# Altium schematic sheet LPC55S69_MINI.SchDoc(LPC55S69_MINI) (continued)

## Library data of the parts
BTN1-BTN3 RESET = B3U-1100P: SWITCH TACTILE SPST-NO 0.05A 12V; Manufacturer: Omron Electronics Inc-EMC Div; Actuator Height off PCB, from Pins: 1.60mm; Actuator Orientation: Top Actuated; Actuator Type: Standard; CategorySort: 700; Contact Rating @ Voltage: 0.05A @ 12VDC; Illumination: Non-Illuminated; Ingress Protection: Dust Proof; Mechanical Life: 200,000 Cycles; Operating Force: 153gf; Outline: 3.00mm x 2.50mm; Switch Function: Off-Mom; Switch Travel: 0.15mm; Actuator Material: -; Category: Switches; Circuit: SPST-NO; Component Kind: Standard; ComponentLink1Description: http://www.components.omron.com/components/web/PDFLIB.nsf/0/2DD3893C7DD33E9E862572900079E9D6/$file/B3U_1110.pdf; ComponentLink1URL: http://www.components.omron.com/components/web/PDFLIB.nsf/0/2DD3893C7DD33E9E862572900079E9D6/$file/B3U_1110.pdf; Features: Grounding Pin; Illumination Type, Color: -; Illumination Voltage (Nominal): -; Library Name: Switches-N.SchLib; Manufacturer Part Number: B3U-1100P; Mounting Type: Surface Mount; Operating Temperature: -25°C ~ 70°C; Packaging: Cut Tape (CT); Pricing 1: 1=1.25, 10=1.201, 25=1.1332, 50=0.997, 100=0.9064, 250=0.86108, 500=0.83842, 1000=0.65714 (USD); RoHS: RoHS Compliant; Stock 1: 45170; Supplier 1: Digi-Key; Supplier Part Number 1: SW1021CT-ND; Termination Style: SMD (SMT) Tab; Value: B3U-1100P
C1-C4 C10 = CGA4J1X7R0J106K125AC: CAP CER 10UF 6.3V X7R 0805; Manufacturer: TDK Corporation; Database Table Name: C0805$; Substitution Notes: 10µF , 6.3V , ±10% , X7R , -55°C ~ 125°C , AEC-Q200 , 0805; Tolerance: ±10%; Category: Capacitors; Component Kind: Standard; ComponentLink1Description: Datasheet (Internal); ComponentLink1URL: datasheets\TDK\mlcc_automotive_general_en.pdf; ComponentLink2Description: Datasheet (External); ComponentLink2URL: https://product.tdk.com/info/en/catalog/datasheets/mlcc_automotive_general_en.pdf; Features: -; Library Name: Capacitors - TDK- AEC-Q200.DbLib; Manufacturer Part Number: CGA4J1X7R0J106K125AC; Operating Temperature: -55°C ~ 125°C; Package: 0805; Ratings: AEC-Q200; Supplier 1: Digikey; Supplier Part Number 1: 445-6971-1-ND; Temperature Coefficient: X7R; Thickness (Max): 1.45MM; Value: 10µF; Voltage - Rated: 6.3V
C5 C7-C9 C11-C13 = CGA2B1X7R1C104K050BC: CAP CER 0.1UF 16V X7R 0402; Manufacturer: TDK Corporation; Database Table Name: C0402$; Substitution Notes: 0.1µF , 16V , ±10% , X7R , -55°C ~ 125°C , AEC-Q200 , 0402; Tolerance: ±10%; Category: Capacitors; Component Kind: Standard; ComponentLink1Description: Datasheet (Internal); ComponentLink1URL: datasheets\TDK\mlcc_automotive_general_en.pdf; ComponentLink2Description: Datasheet (External); ComponentLink2URL: https://product.tdk.com/info/en/catalog/datasheets/mlcc_automotive_general_en.pdf; Features: -; Library Name: Capacitors - TDK- AEC-Q200.DbLib; Manufacturer Part Number: CGA2B1X7R1C104K050BC; Operating Temperature: -55°C ~ 125°C; Package: 0402; Ratings: AEC-Q200; Supplier 1: Digikey; Supplier Part Number 1: 445-5613-1-ND; Temperature Coefficient: X7R; Thickness (Max): 0.55MM; Value: 0.1µF; Voltage - Rated: 16V
C6 = CGA2B2C0G1H470J050BA: CAP CER 47PF 50V C0G 0402; Manufacturer: TDK Corporation; Database Table Name: C0402$; Substitution Notes: 47pF , 50V , ±5% , C0G, NP0 , -55°C ~ 125°C , AEC-Q200 , 0402; Temperature Coefficient: C0G, NP0; Tolerance: ±5%; Category: Capacitors; Component Kind: Standard; ComponentLink1Description: Datasheet (Internal); ComponentLink1URL: datasheets\TDK\mlcc_automotive_general_en.pdf; ComponentLink2Description: Datasheet (External); ComponentLink2URL: https://product.tdk.com/info/en/catalog/datasheets/mlcc_automotive_general_en.pdf; Features: -; Library Name: Capacitors - TDK- AEC-Q200.DbLib; Manufacturer Part Number: CGA2B2C0G1H470J050BA; Operating Temperature: -55°C ~ 125°C; Package: 0402; Ratings: AEC-Q200; Supplier 1: Digikey; Supplier Part Number 1: 445-5592-1-ND; Thickness (Max): 0.55MM; Value: 47pF; Voltage - Rated: 50V
C14 C15 = CGA2B2C0G1H180J050BA: CAP CER 18PF 50V C0G 0402; Manufacturer: TDK Corporation; Database Table Name: C0402$; Substitution Notes: 18pF , 50V , ±5% , C0G, NP0 , -55°C ~ 125°C , AEC-Q200 , 0402; Temperature Coefficient: C0G, NP0; Tolerance: ±5%; Category: Capacitors; Component Kind: Standard; ComponentLink1Description: Datasheet (Internal); ComponentLink1URL: datasheets\TDK\mlcc_automotive_general_en.pdf; ComponentLink2Description: Datasheet (External); ComponentLink2URL: https://product.tdk.com/info/en/catalog/datasheets/mlcc_automotive_general_en.pdf; Features: -; Library Name: Capacitors - TDK- AEC-Q200.DbLib; Manufacturer Part Number: CGA2B2C0G1H180J050BA; Operating Temperature: -55°C ~ 125°C; Package: 0402; Ratings: AEC-Q200; Supplier 1: Digikey; Supplier Part Number 1: 445-5587-1-ND; Thickness (Max): 0.55MM; Value: 18pF; Voltage - Rated: 50V
D1-D3 = BAT760-7: DIODE SCHOTTKY 30V 1A SOD323; Manufacturer: Diodes Inc; Capacitance @ Vr- F: 25pF @ 5V- 1MHz; CategorySort: 400; Current - Reverse Leakage @ Vr: 50µA @ 15V; Database Table Name: Schottky$; Diode Suffix: 1A_2C; Num Pins: 2; Speed: Fast Recovery =< 500ns- > 200mA (Io); Voltage - Forward (Vf) (Max) @ If: 550mV @ 1A; Category: Diodes; Component Kind: Standard; ComponentLink1Description: Internal Datasheet; ComponentLink1URL: http://www.diodes.com/datasheets/ds30498.pdf; ComponentLink2Description: External Datasheet; ComponentLink2URL: http://www.diodes.com/datasheets/ds30498.pdf; Current - Average Rectified (Io): 1A; Diode Type: Schottky; Library Name: Diodes.DbLib; Manufacturer Part Number: BAT760-7; Mounting Type: Surface Mount; Package: SC-76- SOD-323; Packaging: Cut Tape (CT); Reverse Recovery Time (trr): -; SortValue: BAT760-7; Supplier 1: Digi-Key; Supplier Device Package: SOD-323; Supplier Part Number 1: BAT760DICT-ND; Value: BAT760-7; Voltage - DC Reverse (Vr) (Max): 30V
J1 = S2B-PH-SM4-TB(LF)(SN): CONN HEADER PH SIDE 2POS 2MM SMD; Manufacturer: JST Sales America Inc; Connector Type: Header, Shrouded; Number of Positions: 2; Number of Positions Loaded: All; Pitch: 0.079" (2.00mm); Category: Connectors, Interconnects; Color: Natural; Component Kind: Standard; ComponentLink1Description: http://www.jst-mfg.com/product/pdf/eng/ePH.pdf; ComponentLink1URL: http://www.jst-mfg.com/product/pdf/eng/ePH.pdf; Contact Finish: Tin; Contact Finish Thickness: -; Contact Mating Length: -; Contact Type: Male Pin; Fastening Type: -; Features: Solder Retention; Library Name: eConnector_JST_PH.SchLib; Manufacturer Part Number: S2B-PH-SM4-TB(LF)(SN); Mounting Type: Surface Mount, Right Angle; Number of Rows: 1; Packaging: Cut Tape (CT); Pricing 1: 1=0.5, 10=0.457, 100=0.3809, 500=0.3047 (USD); RoHS: RoHS Compliant; Row Spacing: -; Stock 1: 17700; Supplier 1: Digi-Key; Supplier Part Number 1: 455-1749-1-ND; Termination: Solder; Value: S2B-PH-SM4-TB(LF)(SN)
J2 = 10103594-0001LF: CONN RCPT STD MICRO USB TYPE B; Manufacturer: FCI; Connector Type: USB - micro B; Gender: Receptacle; Micro USB B: Value; Notes: Similar to; Number of Contacts: 5; Specifications: USB 2.0; Category: Connectors, Interconnects; Component Kind: Standard; ComponentLink1Description: http://portal.fciconnect.com/res/en/pdffiles/doc_search/10103594.pdf; ComponentLink1URL: http://portal.fciconnect.com/res/en/pdffiles/doc_search/10103594.pdf; Features: Shielded, Solder Retention; Ingress Protection: -; Library Name: eConnector_USB.SchLib; Manufacturer Part Number: 10103594-0001LF; Mounting Type: Surface Mount, Right Angle, Horizontal; Packaging: Cut Tape (CT); Pricing 1: 1=1.1, 10=0.768, 100=0.517, 250=0.43912, 500=0.41716 (USD); RoHS: RoHS Compliant; Stock 1: 23288; Supplier 1: Digi-Key; Supplier Part Number 1: 609-4050-1-ND; Value: USB Micro B
J3 = CORTEX-DEBUG: SAMTEC - FTSH-105-01-F-DV - .050 X .050; Category: Connectors; Component Kind: Standard; Library Name: eConnector.SchLib; Manufacturer: SAMTEC; Manufacturer Part Number: FTSH-105-01-F-DV; Pricing 1: 1=0.974, 25000=0.835, 50000=0.774 (USD); Stock 1: 0; Supplier 1: Newark; Supplier Part Number 1: 01R3399; Value: FTSH-105-01-F-DV
J4 = DM3AT-SF-PEJM5: CONN MICRO SD R/A PUSH-PUSH SMD; Manufacturer: Hirose Electric Co Ltd; Card Type: Secure Digital - microSD™; Connector Type: Connector and Ejector; Insertion, Removal Method: Push In, Push Out; Number of Positions: 10 (8 + 2); Category: Connectors, Interconnects; Component Kind: Standard; ComponentLink1Description: http://www.hirose.co.jp/cataloge_hp/e60900232.pdf; ComponentLink1URL: http://www.hirose.co.jp/cataloge_hp/e60900232.pdf; Contact Finish: Gold; Contact Finish Thickness: -; Ejector Side: -; Features: Switch; Height Above Board: 0.066" (1.68mm); Library Name: eConnector_SD.SchLib; Manufacturer Part Number: DM3AT-SF-PEJM5; Mounting Feature: Normal, Standard - Top; Mounting Type: Surface Mount, Right Angle; Packaging: Cut Tape (CT); Pricing: 1=2.83, 10=2.72, 25=2.4932, 50=2.3798, 100=2.2664, 250=1.98312, 500=1.92648 (USD); RoHS: RoHS Compliant; Stock: 28699; Supplier 1: Digi-Key; Supplier Part Number 1: HR1964CT-ND; Value: DM3AT-SF-PEJM5
L1 = (no symbol name): FIXED IND 4.7UH 520MA 650 MOHM; Manufacturer: Taiyo Yuden; Core Material: Ferrite; DC Resistance (DCR): 650mR; Inductance Tolerance: 20%; Saturation Current: 360mA; Self Resonant Frequency: 45MHz; Shielding: Unshielded; Test Frequency: 7.96MHz; Case Code (Imperial): 0805; Case Code (Metric): 2012; Case/Package: 0805; Component Kind: Standard; ComponentLink1Description: https://datasheet.ciiva.com/15981/214cbc2012t4r7m-15981637.pdf?src-supplier=Onlinecomponents.com; ComponentLink1URL: https://datasheet.ciiva.com/15981/214cbc2012t4r7m-15981637.pdf?src-supplier=Onlinecomponents.com; ComponentLink2Description: https://datasheet.ciiva.com/1040/cbc2012t4r7m-ss-1040456.pdf?src-supplier=Digi-Key; ComponentLink2URL: https://datasheet.ciiva.com/1040/cbc2012t4r7m-ss-1040456.pdf?src-supplier=Digi-Key; ComponentLink3Description: https://datasheet.ciiva.com/8505/cbc2012t4r7m-ss-8505656.pdf?src-supplier=Avnet; ComponentLink3URL: https://datasheet.ciiva.com/8505/cbc2012t4r7m-ss-8505656.pdf?src-supplier=Avnet; ComponentLink4Description: https://datasheet.ciiva.com/8001/wound02-e-8001849.pdf?src-supplier=Element14; ComponentLink4URL: https://datasheet.ciiva.com/8001/wound02-e-8001849.pdf?src-supplier=Element14; Composition: Wirewound; Current Rating: 520mA; Halogen Free: Halogen Free; Height: 1.25mm; Height - Seated (Max): 0.057inch; Inductance: 4.7uH; Lead Free: Exempt; Length: 0.079inch; Library Name: eInductor.SchLib; Manufacturer Part Number: CBC2012T4R7M; Max DC Current: 520mA; Max Operating Temperature: 105°C; Min Operating Temperature: -40°C; Mount: Surface Mount; Number of Pins: 2; Package Quantity: 3000; Packaging: Tape and Reel; Pricing 1: 1=0.24000, 10=0.21600, 100=0.14860, 500=0.12702, 1000=0.09729 (USD); REACH SVHC: No SVHC; RoHS: Yes; Stock 1: 7720; Supplier 1: Digi-Key; Supplier Part Number 1: 587-1602-1-ND; Termination: SMD/SMT; Tolerance: 20%; Value: CBC2012T4R7M; Width: 0.049inch
L2 = (no symbol name): FERRITE BEAD 220 OHM 0402 1LN; Manufacturer: Taiyo Yuden; Current Rating (Max): 1A; DC Resistance (DCR) (Max): 150 mOhm; Filter Type: Power Line; Impedance @ Frequency: 220 Ohm @ 100MHz; Number of Lines: 1; Category: Filters; Component Kind: Standard; ComponentLink1Description: http://ds.yuden.co.jp/TYCOMPAS/ut/detail.do?productNo=BKP1005EM221-T&fileName=BKP1005EM221-T_SS&mode=specSheetDownload; ComponentLink1URL: http://ds.yuden.co.jp/TYCOMPAS/ut/detail.do?productNo=BKP1005EM221-T&fileName=BKP1005EM221-T_SS&mode=specSheetDownload; Height (Max): 0.022" (0.55mm); Library Name: eInductor.SchLib; Manufacturer Part Number: BKP1005EM221-T; Mounting Type: Surface Mount; Operating Temperature: -55°C ~ 85°C; Package / Case: 0402 (1005 Metric); Packaging: Tape & Reel (TR); Pricing 1: 10000=0.0145, 20000=0.014, 30000=0.013, 50000=0.01285 (USD); Ratings: -; RoHS: RoHS Compliant; Size / Dimension: 0.039" L x 0.022" W (1.00mm x 0.55mm); Stock 1: 60000; Supplier 1: Digi-Key; Supplier Part Number 1: 587-3290-2-ND; Value: BKP1005EM221-T
Q1 Q2 = NTR1P02T1G: MOSFET P-CH 20V 1A SOT-23; Manufacturer: ON Semiconductor; Current Rating: -1A; Drain to Source Breakdown Voltage: -20V; Drain to Source Resistance: 148mR; Element Configuration: Single; Fall Time: 9ns; Input Capacitance: 165pF; Max Power Dissipation: 400mW; Nominal Vgs: -1.9V; Number of Elements: 1; Rds On Max: 180mR; Turn-On Delay Time: 7ns; Case/Package: SOT-23-3; Category: MOSFETs; Component Kind: Standard; ComponentLink1Description: https://datasheet.ciiva.com/22641/1708311-22641684.pdf?src-supplier=Element14; ComponentLink1URL: https://datasheet.ciiva.com/22641/1708311-22641684.pdf?src-supplier=Element14; ComponentLink2Description: https://datasheet.ciiva.com/936/ntr1p02t1-d-936864.pdf?src-supplier=Digi-Key; ComponentLink2URL: https://datasheet.ciiva.com/936/ntr1p02t1-d-936864.pdf?src-supplier=Digi-Key; ComponentLink3Description: https://datasheet.ciiva.com/22641/1708311-22641686.pdf?src-supplier=Element14; ComponentLink3URL: https://datasheet.ciiva.com/22641/1708311-22641686.pdf?src-supplier=Element14; ComponentLink4Description: https://datasheet.ciiva.com/26145/ntr1p02t1-d-26145674.pdf?src-supplier=Avnet+Europe; ComponentLink4URL: https://datasheet.ciiva.com/26145/ntr1p02t1-d-26145674.pdf?src-supplier=Avnet+Europe; ComponentLink5Description: https://datasheet.ciiva.com/11168/ntr1p02t1-d-11168174.pdf?src-supplier=Avnet; ComponentLink5URL: https://datasheet.ciiva.com/11168/ntr1p02t1-d-11168174.pdf?src-supplier=Avnet; ComponentLink6Description: https://datasheet.ciiva.com/16386/ntr1p02t1-d-16386335.pdf?src-supplier=Future+Electronics; ComponentLink6URL: https://datasheet.ciiva.com/16386/ntr1p02t1-d-16386335.pdf?src-supplier=Future+Electronics; ComponentLink7Description: https://datasheet.ciiva.com/936/ntr1p02t1-d-936865.pdf?src-supplier=Digi-Key; ComponentLink7URL: https://datasheet.ciiva.com/936/ntr1p02t1-d-936865.pdf?src-supplier=Digi-Key; Contact Plating: Tin,Matte; Continuous Drain Current (ID): 1A; Drain to Source Voltage (Vdss): 20V; Gate to Source Voltage (Vgs): 20V; Height: 0.94mm; Lead Free: Lead Free; Length: 2.9mm; Library Name: eTransistor.SchLib; Manufacturer Part Number: NTR1P02T1G; Max Operating Temperature: 150°C; Min Operating Temperature: -55°C; Number of Pins: 3; On-State Resistance: 148MR; Package Quantity: 1; Packaging: Tape and Reel; Power Dissipation: 400mW; Pricing: 1=0.48000, 10=0.35500, 25=0.31080, 100=0.16870, 500=0.13764, 1000=0.10212 (USD); Radiation Hardening: No; REACH SVHC: No SVHC; Rise Time: 9ns; RoHS: Yes; Stock: 320; Supplier 1: Digi-Key; Supplier Part Number 1: NTR1P02T1GOSCT-ND; Threshold Voltage: -1.9V; Turn-Off Delay Time: 9ns; Value: NTR1P02T1G; Voltage Rating (DC): -20V; Width: 1.3mm
R1 R3 R4 R7 R8 R11 = ERJ-2RKF1002X: RES 10K OHM 1/10W 1% 0402 SMD; Manufacturer: Panasonic Electronic Components; Database Table Name: 1%$; Limiting Element Voltage: 50; Maximum Overload Voltage: 100; Maximum Working Voltage: 31.6V; Package Power: 0402  (0.1W); Power (Watts): 0.1W- 1/10W; Substitution Notes: 10k , ±1% , 0402 , AEC-Q200; Temperature Coefficient: ±100ppm/°C; Category: Resistors; Component Kind: Standard; ComponentLink1Description: Internal Datasheet; ComponentLink1URL: datasheets\Panasonic Electronic Components\AOA0000CE2.pdf; ComponentLink2Description: External Datasheet; ComponentLink2URL: http://industrial.panasonic.com/www-data/pdf/AOA0000/AOA0000CE2.pdf; Composition: Thick Film; Features: -; Height: 0.40MM; Library Name: Resistors - Panasonic - AEC-Q200.DbLib; Manufacturer Part Number: ERJ-2RKF1002X; Number of Terminations: 2; Package: 0402 (1005 Metric); Packaging: Cut Tape (CT); Ratings: AEC-Q200; Resistance (Ohms): 10k; Size / Dimension: 0.039" L x 0.020" W (1.00mm x 0.50mm); Sort Value: 10000; Sort Value Package: 402_10000; Supplier 1: Digi-Key; Supplier Package: 0402; Supplier Part Number 1: P10.0KLCT-ND; Tolerance: ±1%; Value: 10k
R2 = ERJ-3EKF33R0V: RES 33 OHM 1/10W 1% 0603 SMD; Manufacturer: Panasonic Electronic Components; Database Table Name: 1%$; Limiting Element Voltage: 75; Maximum Overload Voltage: 150; Maximum Working Voltage: 1.8V; Package Power: 0603  (0.1W); Power (Watts): 0.1W- 1/10W; Substitution Notes: 33 , ±1% , 0603 , AEC-Q200; Temperature Coefficient: ±100ppm/°C; Category: Resistors; Component Kind: Standard; ComponentLink1Description: Internal Datasheet; ComponentLink1URL: datasheets\Panasonic Electronic Components\AOA0000CE2.pdf; ComponentLink2Description: External Datasheet; ComponentLink2URL: http://industrial.panasonic.com/www-data/pdf/AOA0000/AOA0000CE2.pdf; Composition: Thick Film; Features: -; Height: 0.55MM; Library Name: Resistors - Panasonic - AEC-Q200.DbLib; Manufacturer Part Number: ERJ-3EKF33R0V; Number of Terminations: 2; Package: 0603 (1608 Metric); Packaging: Cut Tape (CT); Ratings: AEC-Q200; Resistance (Ohms): 33; Size / Dimension: 0.063" L x 0.031" W (1.60mm x 0.80mm); Sort Value: 33; Sort Value Package: 603_33; Supplier 1: Digi-Key; Supplier Package: 0603; Supplier Part Number 1: P33.0HCT-ND; Tolerance: ±1%; Value: 33
R5 R6 = ERJ-2RKF33R0X: RES 33 OHM 1/10W 1% 0402 SMD; Manufacturer: Panasonic Electronic Components; Database Table Name: 1%$; Limiting Element Voltage: 50; Maximum Overload Voltage: 100; Maximum Working Voltage: 1.8V; Package Power: 0402  (0.1W); Power (Watts): 0.1W- 1/10W; Substitution Notes: 33 , ±1% , 0402 , AEC-Q200; Temperature Coefficient: ±100ppm/°C; Category: Resistors; Component Kind: Standard; ComponentLink1Description: Internal Datasheet; ComponentLink1URL: datasheets\Panasonic Electronic Components\AOA0000CE2.pdf; ComponentLink2Description: External Datasheet; ComponentLink2URL: http://industrial.panasonic.com/www-data/pdf/AOA0000/AOA0000CE2.pdf; Composition: Thick Film; Features: -; Height: 0.40MM; Library Name: Resistors - Panasonic - AEC-Q200.DbLib; Manufacturer Part Number: ERJ-2RKF33R0X; Number of Terminations: 2; Package: 0402 (1005 Metric); Packaging: Cut Tape (CT); Ratings: AEC-Q200; Resistance (Ohms): 33; Size / Dimension: 0.039" L x 0.020" W (1.00mm x 0.50mm); Sort Value: 33; Sort Value Package: 402_33; Supplier 1: Digi-Key; Supplier Package: 0402; Supplier Part Number 1: P33.0LCT-ND; Tolerance: ±1%; Value: 33
R9 R10 = ERJ-2RKF1001X: RES 1K OHM 1/10W 1% 0402 SMD; Manufacturer: Panasonic Electronic Components; Database Table Name: 1%$; Limiting Element Voltage: 50; Maximum Overload Voltage: 100; Maximum Working Voltage: 10.0V; Package Power: 0402  (0.1W); Power (Watts): 0.1W- 1/10W; Substitution Notes: 1k , ±1% , 0402 , AEC-Q200; Temperature Coefficient: ±100ppm/°C; Category: Resistors; Component Kind: Standard; ComponentLink1Description: Internal Datasheet; ComponentLink1URL: datasheets\Panasonic Electronic Components\AOA0000CE2.pdf; ComponentLink2Description: External Datasheet; ComponentLink2URL: http://industrial.panasonic.com/www-data/pdf/AOA0000/AOA0000CE2.pdf; Composition: Thick Film; Features: -; Height: 0.40MM; Library Name: Resistors - Panasonic - AEC-Q200.DbLib; Manufacturer Part Number: ERJ-2RKF1001X; Number of Terminations: 2; Package: 0402 (1005 Metric); Packaging: Cut Tape (CT); Ratings: AEC-Q200; Resistance (Ohms): 1k; Size / Dimension: 0.039" L x 0.020" W (1.00mm x 0.50mm); Sort Value: 1000; Sort Value Package: 402_1000; Supplier 1: Digi-Key; Supplier Package: 0402; Supplier Part Number 1: P1.00KLCT-ND; Tolerance: ±1%; Value: 1k
R12 = ERJ-3EKF1000V: RES 100 OHM 1/10W 1% 0603 SMD; Manufacturer: Panasonic Electronic Components; Database Table Name: 1%$; Limiting Element Voltage: 75; Maximum Overload Voltage: 150; Maximum Working Voltage: 3.2V; Package Power: 0603  (0.1W); Power (Watts): 0.1W- 1/10W; Substitution Notes: 100 , ±1% , 0603 , AEC-Q200; Temperature Coefficient: ±100ppm/°C; Category: Resistors; Component Kind: Standard; ComponentLink1Description: Internal Datasheet; ComponentLink1URL: datasheets\Panasonic Electronic Components\AOA0000CE2.pdf; ComponentLink2Description: External Datasheet; ComponentLink2URL: http://industrial.panasonic.com/www-data/pdf/AOA0000/AOA0000CE2.pdf; Composition: Thick Film; Features: -; Height: 0.55MM; Library Name: Resistors - Panasonic - AEC-Q200.DbLib; Manufacturer Part Number: ERJ-3EKF1000V; Number of Terminations: 2; Package: 0603 (1608 Metric); Packaging: Cut Tape (CT); Ratings: AEC-Q200; Resistance (Ohms): 100; Size / Dimension: 0.063" L x 0.031" W (1.60mm x 0.80mm); Sort Value: 100; Sort Value Package: 603_100; Stocked: 0; Supplier 1: Digi-Key; Supplier Package: 0603; Supplier Part Number 1: P100HCT-ND; Tolerance: ±1%; Value: 100
SW1 = CHS-01TA: NIDEC COPAL ELECTRONICS - CHS-01TA - DIP SWITCH, 1POS, SPST, SLIDE, SMD; Actuator Type: Slide (Standard); Body Material: Polyphenylene; Current Rating: 100mA; Electrical Life: 2000Cycles; Max Voltage Rating (DC): 24V; Number of Positions: 1; Pitch: 0.05inch; Voltage Rating (DC): 6V; Washable: Yes; Category: DIP Switches; Component Kind: Standard; ComponentLink1Description: https://datasheet.ciiva.com/2863/chs-2863448.pdf?src-supplier=Digi-Key; ComponentLink1URL: https://datasheet.ciiva.com/2863/chs-2863448.pdf?src-supplier=Digi-Key; ComponentLink2Description: https://datasheet.ciiva.com/2863/chs-2863449.pdf?src-supplier=Digi-Key; ComponentLink2URL: https://datasheet.ciiva.com/2863/chs-2863449.pdf?src-supplier=Digi-Key; ComponentLink3Description: https://datasheet.ciiva.com/2863/chs-2863450.pdf?src-supplier=Digi-Key; ComponentLink3URL: https://datasheet.ciiva.com/2863/chs-2863450.pdf?src-supplier=Digi-Key; Contact Material: Copper Alloy; Contact Plating: Gold; Lead Free: Lead Free; Length: 0.098inch; Library Name: eElectroMechanical.SchLib; Manufacturer: Nidec Copal; Manufacturer Part Number: CHS-01TA; Max Operating Temperature: 85°C; Min Operating Temperature: -40°C; Mount: Surface Mount; Orientation: Vertical; Packaging: Tape and Reel; Pricing 1: 1000=0.57079, 2000=0.57024, 4000=0.56944, 6000=0.46309, 8000=0.46247 (USD); RoHS: Yes; Stock 1: 19000; Supplier 1: Avnet; Supplier Part Number 1: CHS-01TA; Termination: J-Hook; Throw Configuration: SPST; Value: CHS-01TA
U1 = (no symbol name): Charge Controller Li-Ion/Li-Pol 15mA to 500mA 4.2V 5-Pin SOT-23 T/R; Manufacturer: Microchip; Component Kind: Standard; ComponentLink1Description: https://datasheet.ciiva.com/1137/630369-1137827.pdf?src-supplier=Element14; ComponentLink1URL: https://datasheet.ciiva.com/1137/630369-1137827.pdf?src-supplier=Element14; ComponentLink2Description: https://datasheet.ciiva.com/15115/getdatasheetpartid-574102-15115383.pdf?src-supplier=Verical; ComponentLink2URL: https://datasheet.ciiva.com/15115/getdatasheetpartid-574102-15115383.pdf?src-supplier=Verical; ComponentLink3Description: https://datasheet.ciiva.com/1932/21984e-1932955.pdf?src-supplier=Digi-Key; ComponentLink3URL: https://datasheet.ciiva.com/1932/21984e-1932955.pdf?src-supplier=Digi-Key; ComponentLink4Description: https://datasheet.ciiva.com/1932/21984e-1932956.pdf?src-supplier=Digi-Key; ComponentLink4URL: https://datasheet.ciiva.com/1932/21984e-1932956.pdf?src-supplier=Digi-Key; ComponentLink5Description: https://datasheet.ciiva.com/1932/21984e-1932957.pdf?src-supplier=Digi-Key; ComponentLink5URL: https://datasheet.ciiva.com/1932/21984e-1932957.pdf?src-supplier=Digi-Key; ComponentLink6Description: https://datasheet.ciiva.com/17299/21984e-17299342.pdf?src-supplier=Avnet+Europe; ComponentLink6URL: https://datasheet.ciiva.com/17299/21984e-17299342.pdf?src-supplier=Avnet+Europe; Library Name: eIC.SchLib; Manufacturer Part Number: MCP73832T-2ATI/OT; Pricing: 1=0.56, 25=0.47, 100=0.42 (USD); RoHS: Yes; Stock: 3196; Supplier 1: Digi-Key; Supplier Part Number 1: MCP73832T-2ATI/OTCT-ND; Value: MCP73832T-2ATI/OT
U2 = (no symbol name): IC REG LINEAR 3.3V 300MA SOT23-5; Manufacturer: Microchip; Accuracy: 2%; Dropout Voltage: 200mV; Input Bias Current: 25uA; Max Input Voltage: 10V; Max Power Dissipation: 250mW; Min Input Voltage: 2V; Number of Outputs: 1; Output Type: Fixed; Polarity: Positive; Quiescent Current: 50uA; Case/Package: SOT-23; Component Kind: Standard; ComponentLink1Description: https://datasheet.ciiva.com/41458/001085944-da-01-en-ic-reg-ld-mcp1802t-3302i-ot-sot-23-5-mcp-41458834.pdf?src-supplier=Conrad; ComponentLink1URL: https://datasheet.ciiva.com/41458/001085944-da-01-en-ic-reg-ld-mcp1802t-3302i-ot-sot-23-5-mcp-41458834.pdf?src-supplier=Conrad; ComponentLink2Description: https://datasheet.ciiva.com/2040/22053c-2040531.pdf?src-supplier=Digi-Key; ComponentLink2URL: https://datasheet.ciiva.com/2040/22053c-2040531.pdf?src-supplier=Digi-Key; ComponentLink3Description: https://datasheet.ciiva.com/16454/filehandler-aspxddocname-en531342-16454309.pdf?src-supplier=Future+Electronics; ComponentLink3URL: https://datasheet.ciiva.com/16454/filehandler-aspxddocname-en531342-16454309.pdf?src-supplier=Future+Electronics; ComponentLink4Description: https://datasheet.ciiva.com/15200/getdatasheetpartid-626522-15200301.pdf?src-supplier=Verical; ComponentLink4URL: https://datasheet.ciiva.com/15200/getdatasheetpartid-626522-15200301.pdf?src-supplier=Verical; ComponentLink5Description: https://datasheet.ciiva.com/2040/22053c-2040529.pdf?src-supplier=Digi-Key; ComponentLink5URL: https://datasheet.ciiva.com/2040/22053c-2040529.pdf?src-supplier=Digi-Key; ComponentLink6Description: https://datasheet.ciiva.com/2040/22053c-2040530.pdf?src-supplier=Digi-Key; ComponentLink6URL: https://datasheet.ciiva.com/2040/22053c-2040530.pdf?src-supplier=Digi-Key; ComponentLink7Description: https://datasheet.ciiva.com/14562/70048283-14562246.pdf?src-supplier=Allied+Electronics; ComponentLink7URL: https://datasheet.ciiva.com/14562/70048283-14562246.pdf?src-supplier=Allied+Electronics; ComponentLink8Description: https://datasheet.ciiva.com/46395/808935-46395672.pdf?src-supplier=Element14; ComponentLink8URL: https://datasheet.ciiva.com/46395/808935-46395672.pdf?src-supplier=Element14; ComponentLink9Description: https://datasheet.ciiva.com/8239/jp531342-8239437.pdf?src-supplier=Avnet; ComponentLink9URL: https://datasheet.ciiva.com/8239/jp531342-8239437.pdf?src-supplier=Avnet; Height: 0.051inch; Lead Free: Lead Free; Length: 0.122inch; Library Name: eIC_Regulator.SchLib; Manufacturer Part Number: MCP1802T-3302I/OT; Max Operating Temperature: 85°C; Max Output Current: 300mA; Min Operating Temperature: -40°C; Min Output Voltage: 3.3V; Mount: Surface Mount; Nominal Output Voltage: 3.3V; Number of Pins: 5; Output Current: 300mA; Output Voltage: 3.3V; Output Voltage Accuracy: 2%; Package Quantity: 3000; Packaging: Tape and Reel; Power Dissipation: 250mW; Pricing 1: 1=0.53000, 25=0.44000, 100=0.40000 (USD); Radiation Hardening: No; REACH SVHC: No SVHC; RoHS: Yes; Stock 1: 3179; Supplier 1: Digi-Key; Supplier Part Number 1: MCP1802T-3302I/OTCT-ND; Value: MCP1802T-3302I/OT; Width: 0.071inch
U3 = (no symbol name): 1.54" 240x240 IPS Display; Manufacturer: Buy Display; Component Kind: Standard; Library Name: eDisplay.SchLib; Manufacturer Part Number: ER-TFT1.54-1; Value: ER-TFT1.54-1
U4 = PRTR5V0U2X,215: TVS DIODE 5.5VWM SOT143B; Manufacturer: NXP Semiconductors; Bidirectional Channels: 2; Capacitance @ Frequency: 1pF @ 1MHz; Power Line Protection: Yes; Voltage - Breakdown (Min): 6V; Voltage - Reverse Standoff (Typ): 5.5V (Max); Applications: General Purpose; Category: Circuit Protection; Component Kind: Standard; ComponentLink1Description: http://www.nxp.com/documents/data_sheet/PRTR5V0U2X.pdf; ComponentLink1URL: http://www.nxp.com/documents/data_sheet/PRTR5V0U2X.pdf; Current - Peak Pulse (10/1000µs): -; Library Name: eDiode.SchLib; Manufacturer Part Number: PRTR5V0U2X,215; Mounting Type: Surface Mount; Operating Temperature: -40°C ~ 85°C (TA); Package / Case: TO-253-4, TO-253AA; Packaging: Cut Tape (CT); Power - Peak Pulse: -; Pricing: 1=0.57, 10=0.477, 25=0.418, 100=0.3578, 250=0.31036, 500=0.26286, 1000=0.20265 (USD); RoHS: RoHS Compliant; Stock: 11734; Supplier 1: Digi-Key; Supplier Device Package: SOT-143B; Supplier Part Number 1: 568-4140-1-ND; Type: Steering (Rail to Rail); Unidirectional Channels: -; Value: PRTR5V0U2X,215; Voltage - Clamping (Max) @ Ipp: -
U5 = (no symbol name): IC MCU 100MHZ CORTEX M33 DUAL; Manufacturer: NXP Semiconductors; Component Kind: Standard; Library Name: eIC.SchLib; Manufacturer Part Number: LPC55S69JEV98K; Pricing: 1=6.94000, 10=6.27000, 25=5.98000, 100=5.19000, 250=4.96000, 500=4.52000 (USD); RoHS: No; Stock: 2365; Supplier 1: Mouser; Supplier Part Number 1: 771-LPC55S69JEV98K; Value: LPC55S69JEV98K
U6 = (no symbol name): 20Hz ~ 10kHz Digital, I²S Microphone MEMS (Silicon) 1.8V Omnidirectional (-26dB ±3dB @ 94dB SPL) Solder Pads; Manufacturer: Knowles Acoustics; Operating Supply Current: 600uA; Shape: Rectangular; Component Kind: Standard; ComponentLink1Description: http://www.knowles.com/eng/content/download/5988/105771/version/4/file/SPH0645LM4H-BRevB.PDF; ComponentLink1URL: http://www.knowles.com/eng/content/download/5988/105771/version/4/file/SPH0645LM4H-BRevB.PDF; ComponentLink2Description: https://media.digikey.com/pdf/Data%20Sheets/Knowles%20Acoustics%20PDFs/SPH0645LM4H-B.pdf; ComponentLink2URL: https://media.digikey.com/pdf/Data%20Sheets/Knowles%20Acoustics%20PDFs/SPH0645LM4H-B.pdf; Direction: Omnidirectional; Height: 1.08mm; Length: 3.5mm; Library Name: eAudio.SchLib; Manufacturer Part Number: SPH0645LM4H-B; Output Type: Digital; Packaging: Tape and Reel; Pricing 1: 1=2.97, 10=2.357, 25=2.1448, 50=2.0076, 100=1.8704, 250=1.70832, 500=1.58364, 1000=1.5587 (USD); Stock 1: 13538; Supplier 1: Digi-Key; Supplier Part Number 1: 423-1405-1-ND; Termination: Solder; Value: SPH0645LM4H-B; Voltage Rating: 1.8V; Width: 2.65mm
U7 = (no symbol name): Crystal 16MHz ±20ppm (Tol) ±50ppm (Stability) 18pF FUND 50Ohm 2-Pin CSMD T/R; Manufacturer: Abracon; Manufacturer Part Number: ABM3-16.000MHZ-B2-T; ESR (Equivalent Series Resistance): 40R; Series Resistance: 60R; Accuracy: 20ppm; Case/Package: CSMD; Category: Crystals; Component Kind: Standard; ComponentLink1Description: https://datasheet.ciiva.com/15116/getdatasheetpartid-719852-15116752.pdf?src-supplier=Verical; ComponentLink1URL: https://datasheet.ciiva.com/15116/getdatasheetpartid-719852-15116752.pdf?src-supplier=Verical; ComponentLink2Description: https://datasheet.ciiva.com/4945/abm3-47699-4945240.pdf?src-supplier=Mouser; ComponentLink2URL: https://datasheet.ciiva.com/4945/abm3-47699-4945240.pdf?src-supplier=Mouser; ComponentLink3Description: https://datasheet.ciiva.com/855/abm3-855737.pdf?src-supplier=Digi-Key; ComponentLink3URL: https://datasheet.ciiva.com/855/abm3-855737.pdf?src-supplier=Digi-Key; ComponentLink4Description: https://datasheet.ciiva.com/855/abm3-855738.pdf?src-supplier=Digi-Key; ComponentLink4URL: https://datasheet.ciiva.com/855/abm3-855738.pdf?src-supplier=Digi-Key; ComponentLink5Description: https://datasheet.ciiva.com/855/abm3-855739.pdf?src-supplier=Digi-Key; ComponentLink5URL: https://datasheet.ciiva.com/855/abm3-855739.pdf?src-supplier=Digi-Key; ComponentLink6Description: https://datasheet.ciiva.com/16209/abm3-16209965.pdf?src-supplier=Future+Electronics; ComponentLink6URL: https://datasheet.ciiva.com/16209/abm3-16209965.pdf?src-supplier=Future+Electronics; Composition: Ceramic; Depth: 3.2mm; Frequency: 16MHz; Frequency Stability: 50ppm; Frequency Tolerance: 20ppm; Height: 0.051inch; Lead Free: Lead Free; Length: 5mm; Library Name: eCrystal.SchLib; Load Capacitance: 18pF; Max Operating Temperature: 70°C; Min Operating Temperature: -20°C; Mount: Surface Mount; Number of Pins: 2; Package Quantity: 1000; Packaging: Tape and Reel; Pricing: 1=0.66000, 10=0.55300, 50=0.49780, 100=0.44260, 500=0.42044 (USD); REACH SVHC: Yes; RoHS: Yes; Stock: 9690; Supplier 1: Digi-Key; Supplier Part Number 1: 535-9103-1-ND; Termination: SMD/SMT; Tolerance: 20ppm; Value: 16MHz; Width: 3.2mm
U8 = (no symbol name): IC TXRX RS422/485 20MBPS 10MSOP; Manufacturer: Intersil; Duplex: Full; Number of Drivers/Receivers: 1/1; Protocol: RS422, RS485; Voltage - Supply: 3 V ~ 3.6 V; Category: Integrated Circuits (ICs); Component Kind: Standard; ComponentLink1Description: http://www.intersil.com/content/dam/intersil/documents/isl3/isl3170e-71e-72e-73e-74e-75e-76e-77e-78e.pdf; ComponentLink1URL: http://www.intersil.com/content/dam/intersil/documents/isl3/isl3170e-71e-72e-73e-74e-75e-76e-77e-78e.pdf; Data Rate: 20Mbps; Library Name: eIC.SchLib; Manufacturer Part Number: ISL3176EIUZ-T; Mounting Type: Surface Mount; Operating Temperature: -40°C ~ 85°C; Package / Case: 10-TFSOP, 10-MSOP (0.118", 3.00mm Width); Packaging: Cut Tape (CT); Part Status: Active; Pricing 1: 1=1.79, 10=1.61, 100=1.2944, 500=1.06348, 1000=0.88117 (USD); RoHS: RoHS Compliant; Stock 1: 9164; Supplier 1: Digi-Key; Supplier Device Package: 10-MSOP; Supplier Part Number 1: ISL3176EIUZ-TCT-ND; Type: Transceiver; Value: ISL3176EIUZ-T
P1-P22 = (no symbol name): Library Name: eConnector.SchLib
